# Altium schematic sheet Sheet2.SchDoc(Sheet2)
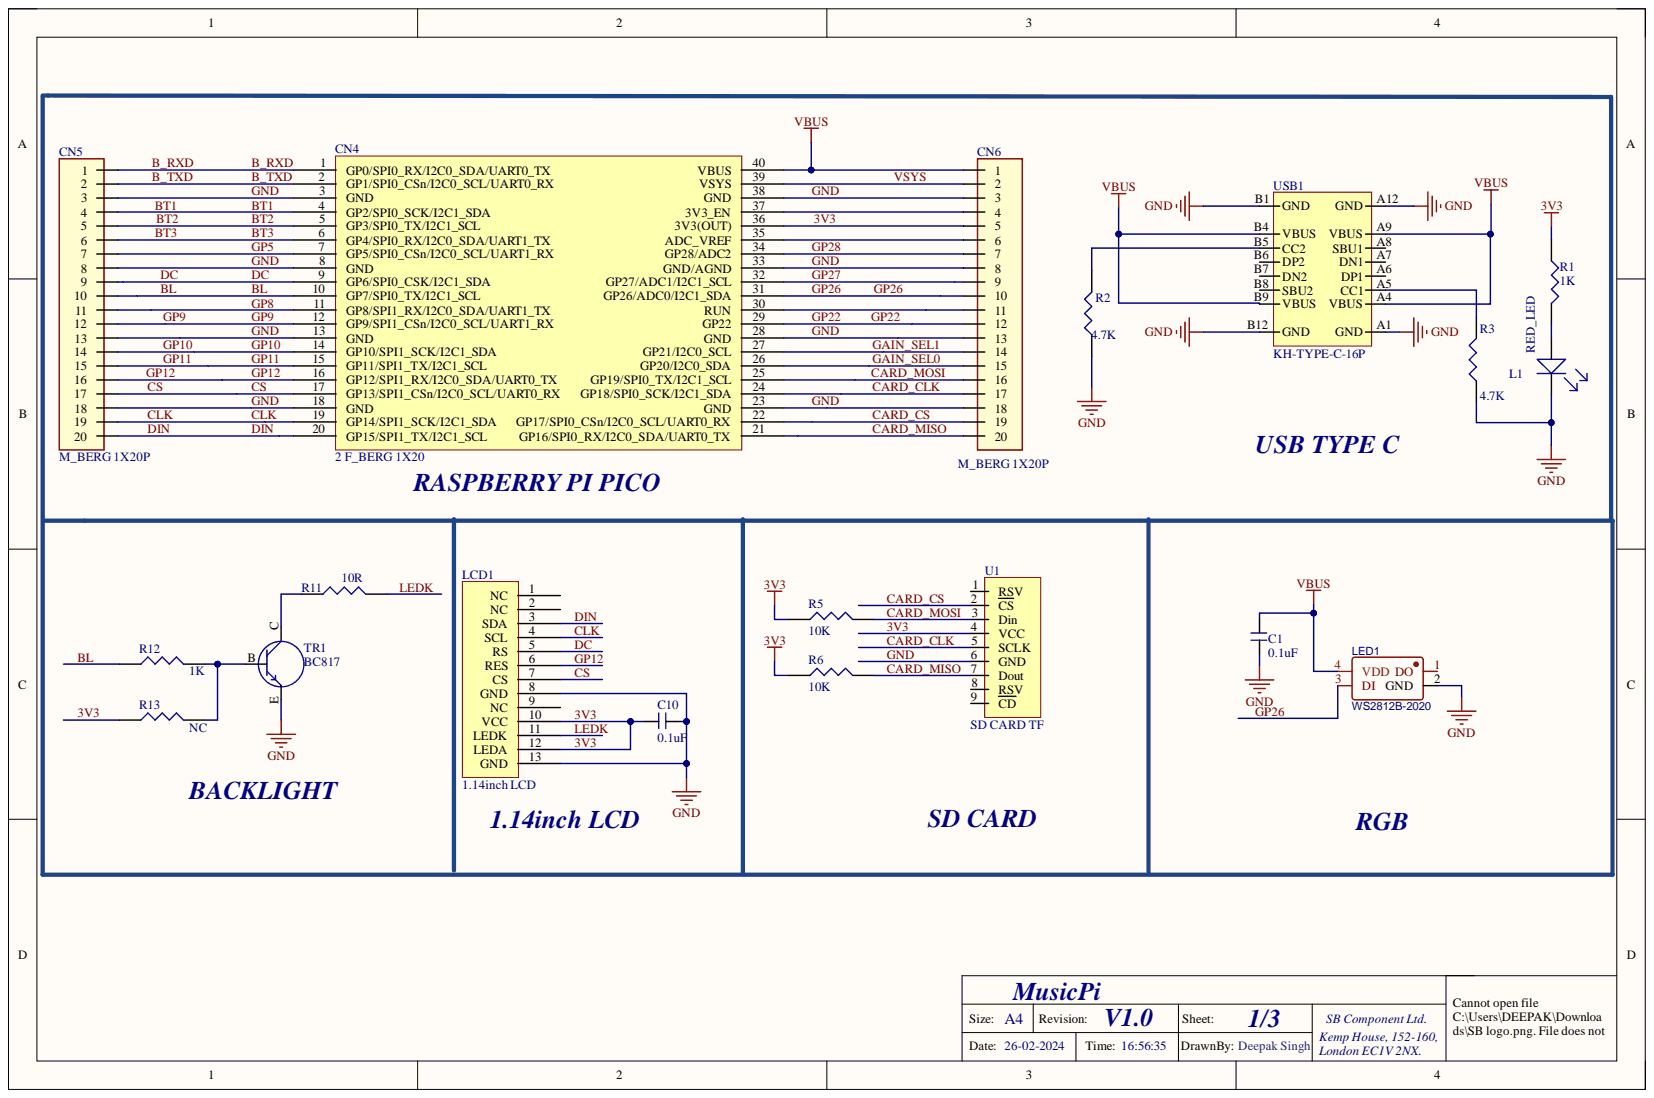
[diagram p0: Sheet2.SchDoc]

## Parts
C1  0.1uF  [C_0603]
C10  0.1uF  [C_0603]
CN4  2 F_BERG 1X20  [PICO_TH]
CN5  M_BERG 1X20P  [CON20_2p5mm]
CN6  M_BERG 1X20P  [CON20_2p5mm]
L1  RED_LED  [LED_0603]
LCD1  1.14inch LCD  [1.14 inch LCD]
LED1  WS2812B-2020  [WS2812B_20X20]
R1  1K  [R_0603]
R2  4.7K  [R_0603]
R3  4.7K  [R_0603]
R5  10K  [R_0603]
R6  10K  [R_0603]
R11  10R  [R_0603]
R12  1K  [R_0603]
R13  NC  [R_0603]
TR1  BC817  [SOT23]
U1  SD CARD TF  [micro sd card]
USB1  KH-TYPE-C-16P  [USB TYPE _C]

## Nets
VBUS: C1.1 CN4.40(VBUS) CN6.1 LED1.4(VDD) USB1.A4(VBUS) USB1.A9(VBUS) USB1.B4(VBUS) USB1.B9(VBUS)
3V3: C10.2 CN4.36(3V3(OUT)) CN6.5 LCD1.10(VCC) LCD1.12(LEDA) R1.1 R5.1 R6.1 R13.1 U1.4(VCC)
B_RXD: CN4.1(GP0/SPI0_RX/I2C0_SDA/UART0_TX) CN5.1
B_TXD: CN4.2(GP1/SPI0_CSn/I2C0_SCL/UART0_RX) CN5.2
BL: CN4.10(GP7/SPI0_TX/I2C1_SCL) CN5.10 R12.1
BT1: CN4.4(GP2/SPI0_SCK/I2C1_SDA) CN5.4
BT2: CN4.5(GP3/SPI0_TX/I2C1_SCL) CN5.5
BT3: CN4.6(GP4/SPI0_RX/I2C0_SDA/UART1_TX) CN5.6
CARD_CLK: CN4.24(GP18/SPI0_SCK/I2C1_SDA) CN6.17 U1.5(SCLK)
CARD_CS: CN4.22(GP17/SPI0_CSn/I2C0_SCL/UART0_RX) CN6.19 U1.2(CS)
CARD_MISO: CN4.21(GP16/SPI0_RX/I2C0_SDA/UART0_TX) CN6.20 R6.2 U1.7(Dout)
CARD_MOSI: CN4.25(GP19/SPI0_TX/I2C1_SCL) CN6.16 R5.2 U1.3(Din)
CLK: CN4.19(GP14/SPI1_SCK/I2C1_SDA) CN5.19 LCD1.4(SCL)
CS: CN4.17(GP13/SPI1_CSn/I2C0_SCL/UART0_RX) CN5.17 LCD1.7(CS)
DC: CN4.9(GP6/SPI0_CSK/I2C1_SDA) CN5.9 LCD1.5(RS)
DIN: CN4.20(GP15/SPI1_TX/I2C1_SCL) CN5.20 LCD1.3(SDA)
GAIN_SEL0: CN4.26(GP20/I2C0_SDA) CN6.15
GAIN_SEL1: CN4.27(GP21/I2C0_SCL) CN6.14
GND: C1.2 C10.1 CN4.3(GND) CN4.8(GND) CN4.13(GND) CN4.18(GND) CN4.23(GND) CN4.28(GND) CN4.33(GND/AGND) CN4.38(GND) CN5.3 CN5.8 CN5.13 CN5.18 CN6.3 CN6.8 CN6.13 CN6.18 L1.K LCD1.8(GND) LCD1.13(GND) LED1.2(GND) R2.1 R3.1 TR1.E U1.6(GND) USB1.A1(GND) USB1.A12(GND) USB1.B1(GND) USB1.B12(GND)
GP5: CN4.7(GP5/SPI0_CSn/I2C0_SCL/UART1_RX) CN5.7
GP8: CN4.11(GP8/SPI1_RX/I2C0_SDA/UART1_TX) CN5.11
GP9: CN4.12(GP9/SPI1_CSn/I2C0_SCL/UART1_RX) CN5.12
GP10: CN4.14(GP10/SPI1_SCK/I2C1_SDA) CN5.14
GP11: CN4.15(GP11/SPI1_TX/I2C1_SCL) CN5.15
GP12: CN4.16(GP12/SPI1_RX/I2C0_SDA/UART0_TX) CN5.16 LCD1.6(RES)
GP22: CN4.29(GP22) CN6.12
GP26: CN4.31(GP26/ADC0/I2C1_SDA) CN6.10 LED1.3(DI)
GP27: CN4.32(GP27/ADC1/I2C1_SCL) CN6.9
GP28: CN4.34(GP28/ADC2) CN6.7
LEDK: LCD1.11(LEDK) R11.2
VSYS: CN4.39(VSYS) CN6.2
NetCN4_30: CN4.30(RUN) CN6.11
NetCN4_35: CN4.35(ADC_VREF) CN6.6
NetCN4_37: CN4.37(3V3_EN) CN6.4
NetL1_A: L1.A R1.2
NetR2_2: R2.2 USB1.B5(CC2)
NetR3_2: R3.2 USB1.A5(CC1)
NetR11_1: R11.1 TR1.C
NetR12_2: R12.2 R13.2 TR1.B

Not connected: LCD1 1(NC) 2(NC) 9(NC); LED1 1(DO); U1 1(RSV) 8(RSV) 9(CD); USB1 A6(DP1) A7(DN1) A8(SBU1) B6(DP2) B7(DN2) B8(SBU2)

## Library data of the parts
C1 C10 = CAP_NONPOLAR: Component Kind: Standard; Library Name: dxp_pasl_lib.IntLib
CN4 = CON40_PICO: Component Kind: Standard; Library Name: dxp_pasl_lib.IntLib
CN5 CN6 = CON20: Component Kind: Standard; Library Name: dxp_pasl_lib.IntLib
L1 = LED: Component Kind: Standard; Library Name: dxp_pasl_lib.IntLib
LCD1 = LCD 1.14INCH: 1.14inch LCD Display 65K RGB Colors, 240×135 Pixels, SPI Interface; Component Kind: Standard; Library Name: dxp_pasl_lib.IntLib
LED1 = WS2812B-2020: BOM_Manufacturer: worldsemi; Contributor: LCSC; BOM_JLCPCB Part Class: Extended Part; BOM_Manufacturer Part: WS2812B-2020; BOM_Supplier: LCSC; BOM_Supplier Part: C965555; Component Kind: Standard; spicePre: L; spiceSymbolName: WS2812B-2020
R1-R3 R5 R6 R11-R13 = RES: Component Kind: Standard; Library Name: dxp_pasl_lib.IntLib
TR1 = TRANSISTER_NPN: Component Kind: Standard; Library Name: dxp_pasl_lib.IntLib
U1 = SD CARD TF: Micro SD Memory Card Socket; Component Kind: Standard; Library Name: dxp_pasl_lib.IntLib
USB1 = USB TYPE-C KH-TYPE-C-16P: 3A 1 Surface Mount 16 Female -40?~+85? Type-C SMD USB Connectors ROHS #  C709357; Component Kind: Standard; ComponentLink2Description: New link; ComponentLink2URL: https://lcsc.com/product-detail/USB-Connectors_Shenzhen-Kinghelm-Elec-KH-TYPE-C-16P_C709357.html; Supplier 1: LCSC; Supplier Part Number 1: C165948; Library Name: dxp_pasl_lib.IntLib
